# Altium schematic sheet On_Board_Peripherals.SchDoc(On_Board_Peripherals)
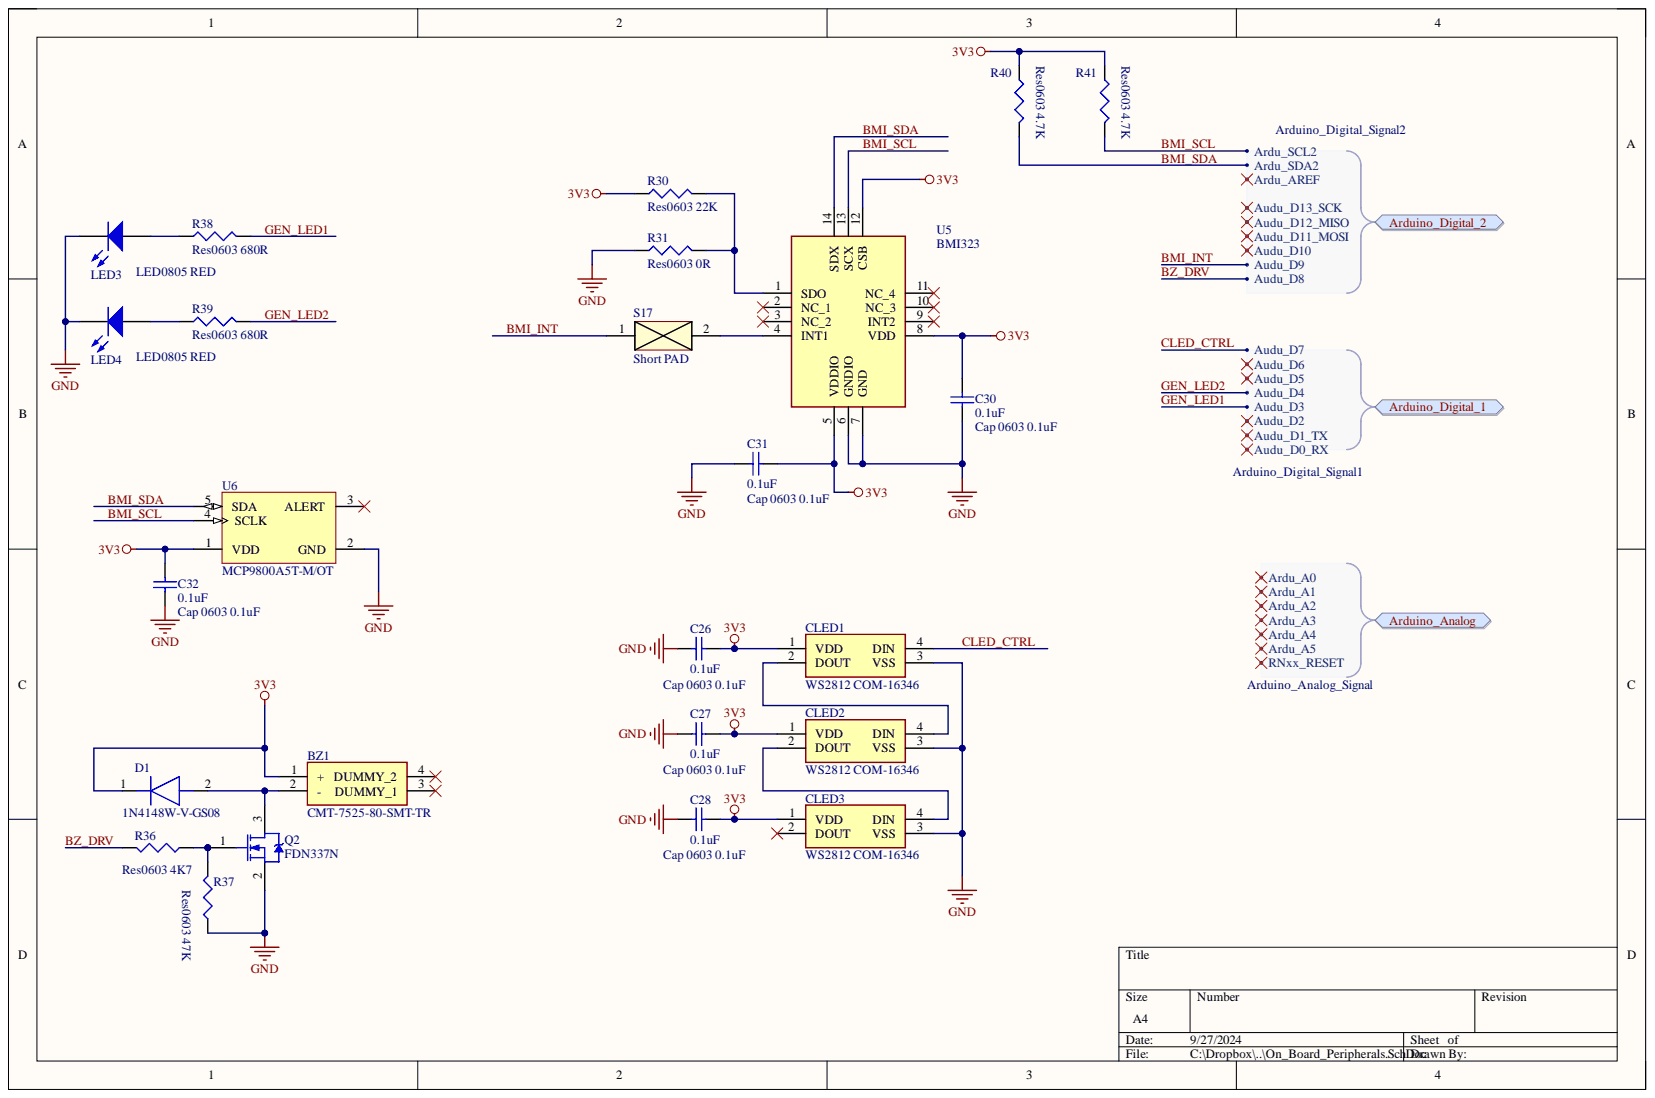
[diagram p0: On_Board_Peripherals.SchDoc]

## Parts
BZ1  CMT-7525-80-SMT-TR  [CMT752580SMTTR]
C26  Cap 0603 0.1uF  value 0.1uF  [0603C]
C27  Cap 0603 0.1uF  value 0.1uF  [0603C]
C28  Cap 0603 0.1uF  value 0.1uF  [0603C]
C30  Cap 0603 0.1uF  value 0.1uF  [0603C]
C31  Cap 0603 0.1uF  value 0.1uF  [0603C]
C32  Cap 0603 0.1uF  value 0.1uF  [0603C]
CLED1  WS2812 COM-16346  [COM16346]
CLED2  WS2812 COM-16346  [COM16346]
CLED3  WS2812 COM-16346  [COM16346]
D1  1N4148W-V-GS08  [1N4148WVGS08]
LED3  LED0805 RED  [LED0805_R]
LED4  LED0805 RED  [LED0805_R]
Q2  FDN337N  [MMBT_SOT23_N]
R30  Res0603 22K  value 22K  [0603R]
R31  Res0603 0R  value 0R  [0603R]
R36  Res0603 4K7  value 4K7  [0603R]
R37  Res0603 47K  [0603R]
R38  Res0603 680R  [0603R]
R39  Res0603 680R  [0603R]
R40  Res0603 4.7K  [0603R]
R41  Res0603 4.7K  [0603R]
S17  Short PAD  [Short_PAD]
U5  BMI323  [BMI323]
U6  MCP9800A5T-M/OT  [MCP1754_SOT-23-CT5_M]

## Ports
Arduino_Analog, Arduino_Analog.Ardu_A0, Arduino_Analog.Ardu_A1, Arduino_Analog.Ardu_A2, Arduino_Analog.Ardu_A3, Arduino_Analog.Ardu_A4, Arduino_Analog.Ardu_A5, Arduino_Analog.RNxx_RESET, Arduino_Digital_1, Arduino_Digital_1.Audu_D0_RX, Arduino_Digital_1.Audu_D1_TX, Arduino_Digital_1.Audu_D2, Arduino_Digital_1.Audu_D3, Arduino_Digital_1.Audu_D4, Arduino_Digital_1.Audu_D5, Arduino_Digital_1.Audu_D6, Arduino_Digital_1.Audu_D7, Arduino_Digital_2, Arduino_Digital_2.Ardu_AREF, Arduino_Digital_2.Ardu_SCL2, Arduino_Digital_2.Ardu_SDA2, Arduino_Digital_2.Audu_D8, Arduino_Digital_2.Audu_D9, Arduino_Digital_2.Audu_D10, Arduino_Digital_2.Audu_D11_MOSI, Arduino_Digital_2.Audu_D12_MISO, Arduino_Digital_2.Audu_D13_SCK

## Nets
3V3: BZ1.1(+) C26.2 C27.2 C28.2 C30.2 C31.2 C32.2 CLED1.1(VDD) CLED2.1(VDD) CLED3.1(VDD) D1.1 R30.1 R40.1 R41.1 U5.5(VDDIO) U5.8(VDD) U5.12(CSB) U6.1(VDD)
GND: C26.1 C27.1 C28.1 C30.1 C31.1 C32.1 CLED1.3(VSS) CLED2.3(VSS) CLED3.3(VSS) LED3.2 LED4.2 Q2.2 R31.1 R37.1 U5.6(GNDIO) U5.7(GND) U6.2(GND)
BMI_INT: S17.1 ; port Arduino_Digital_2
BMI_SCL: R41.2 U5.13(SCX) U6.4(SCLK) ; port Arduino_Digital_2
BMI_SDA: R40.2 U5.14(SDX) U6.5(SDA) ; port Arduino_Digital_2
BZ_DRV: R36.2 ; port Arduino_Digital_2
CLED_CTRL: CLED1.4(DIN) ; port Arduino_Digital_1
GEN_LED1: R38.2 ; port Arduino_Digital_1
GEN_LED2: R39.2 ; port Arduino_Digital_1
NetBZ1_2: BZ1.2(-) D1.2 Q2.3
NetCLED1_2: CLED1.2(DOUT) CLED2.4(DIN)
NetCLED2_2: CLED2.2(DOUT) CLED3.4(DIN)
NetLED3_1: LED3.1 R38.1
NetLED4_1: LED4.1 R39.1
NetQ2_1: Q2.1 R36.1 R37.2
NetR30_2: R30.2 R31.2 U5.1(SDO)
NetS17_2: S17.2 U5.4(INT1)
N00003: ; port Arduino_Analog
N00005: ; port Arduino_Digital_1
N00007: ; port Arduino_Analog
N00009: ; port Arduino_Analog
N00012: ; port Arduino_Analog
N00015: ; port Arduino_Analog
N00018: ; port Arduino_Analog
N00022: ; port Arduino_Digital_1
N00024: ; port Arduino_Digital_1
N00030: ; port Arduino_Digital_1
N00032: ; port Arduino_Digital_1
N00039: ; port Arduino_Digital_2
N00042: ; port Arduino_Digital_2
N00045: ; port Arduino_Digital_2
N00048: ; port Arduino_Digital_2
N00051: ; port Arduino_Digital_2
N00057: ; port Arduino_Analog

Not connected: BZ1 3(DUMMY_1) 4(DUMMY_2); CLED3 2(DOUT); U5 2(NC_1) 3(NC_2) 9(INT2) 10(NC_3) 11(NC_4); U6 3(ALERT)

## Library data of the parts
BZ1 = CMT-7525-80-SMT-TR: Loudspeaker or Buzzer; Manufacturer_Name: CUI Devices; Arrow Part Number: CMT-7525-80-SMT-TR; Arrow Price/Stock: https://www.arrow.com/en/products/cmt-7525-80-smt-tr/cui-devices?region=nac; Component Kind: Standard; Datasheet Link: https://www.cuidevices.com/product/resource/cmt-7525-80-smt-tr.pdf; Height: 2.7mm; Manufacturer_Part_Number: CMT-7525-80-SMT-TR; Mouser Part Number: 490-CMT-7525-80SMTTR; Mouser Price/Stock: https://www.mouser.co.uk/ProductDetail/CUI-Devices/CMT-7525-80-SMT-TR?qs=P1JMDcb91o5izP7Xira9ww%3D%3D
C26-C28 C30-C32 = Cap 0603: Capacitor (Semiconductor SIM Model); Component Kind: Standard; LatestRevisionDate: 29-May-2009; LatestRevisionNote: IPC-7351 Footprint Added.; Library Name: MCHP_Parts_2016.SchLib; PackageDescription: Chip Capacitor; PackageReference: C0603; Published: 8-Jun-2000; Publisher: Altium Limited
CLED1-CLED3 = COM-16346: Integrated Circuit; Manufacturer_Name: SparkFun; Arrow Part Number: COM-16346; Arrow Price/Stock: https://www.arrow.com/en/products/com-16346/sparkfun-electronics?region=nac; Component Kind: Standard; Datasheet Link: http://cdn.sparkfun.com/datasheets/BreakoutBoards/WS2812B.pdf; Height: 2.25mm; Manufacturer_Part_Number: COM-16346; Mouser Part Number: 474-COM-16346; Mouser Price/Stock: https://www.mouser.co.uk/ProductDetail/SparkFun/COM-16346?qs=OlC7AqGiEDnspdV1Dchx7w%3D%3D
D1 = 1N4148W-V-GS08: Diode; Manufacturer_Name: Vishay; Arrow Part Number: 1N4148W-V-GS08; Arrow Price/Stock: https://www.arrow.com/en/products/1n4148w-v-gs08/vishay; Component Kind: Standard; Datasheet Link: https://us.rs-online.com/m/d/a2789c8794cb0c42ced29f2dd8571f3c.pdf; Height: 1.35mm; Manufacturer_Part_Number: 1N4148W-V-GS08; Mouser Part Number: 625-1N4148W-V; Mouser Price/Stock: https://www.mouser.co.uk/ProductDetail/Vishay-Semiconductors/1N4148W-V-GS08?qs=TNTIDjy6APrS%2Fdgq1RSs3A%3D%3D
LED3 LED4 = LED0805 RED: Typical BLUE SiC LED; Component Kind: Standard; DatasheetVersion: 16-Apr-2002; LatestRevisionDate: 17-Dec-2003; LatestRevisionNote: PCB Footprint replaced.; Library Name: MCHP_Parts_2016.SchLib; PackageDescription: SM LED; 2 C-Bend Leads; Body 3.5 x 2.8 x 1.9 mm, inc leads (LxWxH typ); PackageReference: 3.5X2.8X1.9; PackageVersion: 16-Apr-2002; Published: 8-Jun-2000; Publisher: Altium Limited
Q2 = FDN337N: N Channel MOSFET; Code_JEDEC: TO-254-AA; Component Kind: Standard; ComponentLink1Description: Manufacturer Link; ComponentLink1URL: http://www.irf.com/; ComponentLink2Description: Datasheet; ComponentLink2URL: http://www.irf.com/product-info/datasheets/data/IRFM460.pdf; DatasheetVersion: PD-9.486C; Library Name: MCHP_Parts_2016.SchLib; PackageDescription: TO, Thru-Hole, Vertical, Heatsink Mounted; 3 In-Line Leads; Pitch 3.81 mm; PackageReference: TO-254AA; Published: 17-Sep-2003; Publisher: Altium Limited
R30 R31 R36 = Res0603: Resistor; Component Kind: Standard; LatestRevisionDate: 29-May-2009; LatestRevisionNote: IPC-7351 Footprint Added.; Library Name: MCHP_Parts_2016.SchLib; PackageDescription: Chip Resistor; PackageReference: J1-0603; Published: 8-Jun-2000; Publisher: Altium Limited
R37-R39 = Res0603: Resistor; Value: 680; Component Kind: Standard; LatestRevisionDate: 29-May-2009; LatestRevisionNote: IPC-7351 Footprint Added.; Library Name: MCHP_Parts_2016.SchLib; PackageDescription: Chip Resistor; PackageReference: J1-0603; Published: 8-Jun-2000; Publisher: Altium Limited
R40 R41 = Res0603: Resistor; Value: 4K7; Component Kind: Standard; LatestRevisionDate: 29-May-2009; LatestRevisionNote: IPC-7351 Footprint Added.; Library Name: MCHP_Parts_2016.SchLib; PackageDescription: Chip Resistor; PackageReference: J1-0603; Published: 8-Jun-2000; Publisher: Altium Limited
S17 = Short_Pads: Component Kind: Standard; Library Name: MCHP_Parts_2016.SchLib
U5 = BMI323: Integrated Circuit; Manufacturer_Name: BOSCH; Arrow Part Number: BMI323; Arrow Price/Stock: null?region=nac; Component Kind: Standard; Datasheet Link: https://www.bosch-sensortec.com/media/boschsensortec/downloads/datasheets/bst-bmi323-ds000.pdf; Height: 0.87mm; Manufacturer_Part_Number: BMI323; Mouser Part Number: 262-BMI323; Mouser Price/Stock: https://www.mouser.co.uk/ProductDetail/Bosch-Sensortec/BMI323?qs=By6Nw2ByBD3%2FE1v0UqNQPQ%3D%3D
U6 = MCP9800A5T-M/OT: 2-Wire High-Accuracy Temperature Sensor, Slave Address 101, 5-Pin SOT-23, -55蚓 to +125蚓 Temperature, Tape and Reel; Code_IPC: SOT23-5; Component Kind: Standard; ComponentLink1Description: Datasheet; ComponentLink1URL: http://ww1.microchip.com/downloads/en/DeviceDoc/21909d.pdf; ComponentLink2Description: Packaging Specification; ComponentLink2URL: http://www.microchip.com/stellent/groups/techpub_sg/documents/packagingspec/en012702.pdf; ComponentLink3Description: Microchip.com Home; ComponentLink3URL: http://www.microchip.com/; DatasheetVersion: revD, Nov-2010; LatestRevisionDate: 04-Jan-2011; LatestRevisionNote: Sch pins 4 & 5 swapped.; Library Name: MCHP_Parts_2016.SchLib; PackageDescription: 5-Lead Plastic Small Outline Transistor (OT) [SOT-23]; PackageReference: SOT-23-OT5; PackageVersion: revBB, Aug-2009; Published: 29-Jan-2009; Supplier 1: Digi-Key; Supplier 2: Digi-Key; Supplier 3: Digi-Key; Supplier Part Number 1: MCP9800A5T-M/OTTR-ND; Supplier Part Number 2: MCP9800A5T-M/OTCT-ND; Supplier Part Number 3: MCP9800A5T-M/OTDKR-ND; Publisher: Altium Limited
